# Altium schematic sheet JacdacAccessSwitchInput 34.SchDoc
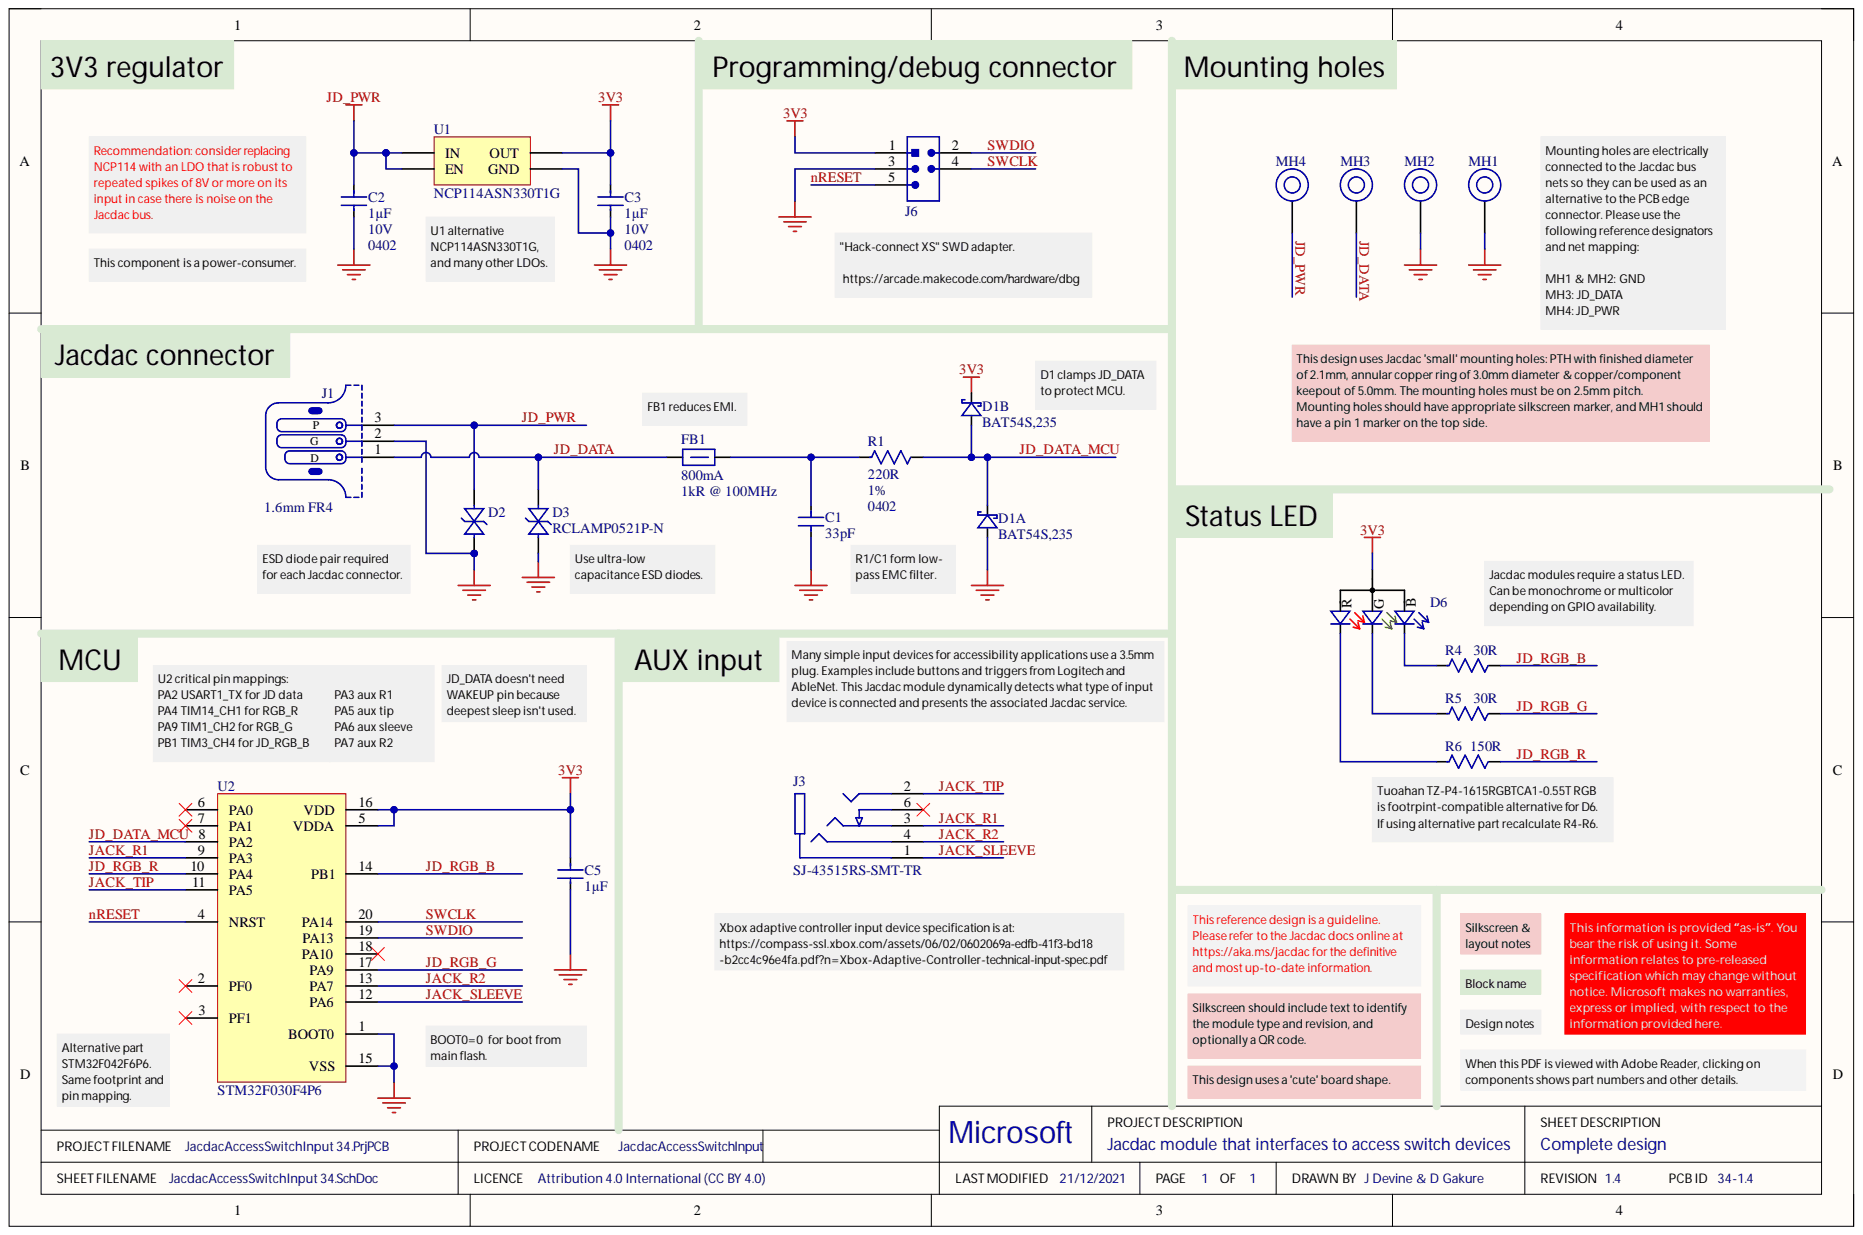
[diagram p0: JacdacAccessSwitchInput 34.SchDoc]

## Parts
C1  Capacitance: 33pF
C2  Capacitance: 1µF  Case Code (Imperial): 0402  Voltage Rating: 10V
C3  Capacitance: 1µF  Case Code (Imperial): 0402  Voltage Rating: 10V
C5  Capacitance: 1µF
D1  Manufacturer Part Number: BAT54S,235  (parts A,B)
D2  (no value)
D3  Manufacturer Part Number: RCLAMP0521P-N
D6  (no value)
FB1  Current Rating: 800mA  Impedance: 1kR @ 100MHz
J1  PCB Type: 1.6mm FR4
J3  Manufacturer Part Number: SJ-43515RS-SMT-TR
J6  (no value)
MH1  (no value)
MH2  (no value)
MH3  (no value)
MH4  (no value)
R1  Resistance: 220R  Tolerance: 1%
R4  Resistance: 30R
R5  Resistance: 30R
R6  Resistance: 150R
U1  Manufacturer Part Number: NCP114ASN330T1G
U2  Manufacturer Part Number: STM32F030F4P6

## Nets
3V3: C3.2 C5.2 D1.2 D6.1 J6.1 U1.5(OUT) U2.5(VDDA) U2.16(VDD)
GND: C1.1 C2.1 C3.1 C5.1 D1.1 D2.2 D3.2 J1.2 J6.3 MH1.1 MH2.1 U1.2(GND) U2.1(BOOT0) U2.15(VSS)
JACK_R1: J3.3 U2.9(PA3)
JACK_R2: J3.4 U2.13(PA7)
JACK_SLEEVE: J3.1 U2.12(PA6)
JACK_TIP: J3.2 U2.11(PA5)
JD_DATA: D3.1 FB1.1 J1.1 MH3.1
JD_DATA_MCU: D1.3 R1.1 U2.8(PA2)
JD_PWR: C2.2 D2.1 J1.3 MH4.1 U1.1(IN) U1.3(EN)
JD_RGB_B: R4.2 U2.14(PB1)
JD_RGB_G: R5.2 U2.17(PA9)
JD_RGB_R: R6.2 U2.10(PA4)
nRESET: J6.5 U2.4(NRST)
SWCLK: J6.4 U2.20(PA14)
SWDIO: J6.2 U2.19(PA13)
NetC1_2: C1.2 FB1.2 R1.2
NetD6_2: D6.2 R6.1
NetD6_3: D6.3 R5.1
NetD6_4: D6.4 R4.1

Not connected: J3 6; U2 2(PF0) 3(PF1) 6(PA0) 7(PA1) 18(PA10)

## Library data of the parts
C1 = (no symbol name): CAP CER 33PF 50V NP0 0402; Manufacturer: Samsung; Manufacturer Part Number: CL05C330JB51PNC; Tolerance: 5%; Case Code (Imperial): 0402; Case Code (Metric): 1005; Case/Package: 0402; Category: Capacitors; ComponentLink1Description: Samsung datasheet online; ComponentLink1URL: http://www.samsungsem.com/kr/support/library/product-catalog/mlcc.jsp; Max Operating Temperature: 125°C; Min Operating Temperature: -55°C; Ratings: AEC-Q200; Supplier 1: Digi-Key; Supplier 2: Mouser; Supplier 3: Future Electronics; Supplier Part Number 1: 1276-6515-1-ND; Supplier Part Number 2: 187-CL05C330JB51PNC; Supplier Part Number 3: 6022520; Voltage Rating: 50V
C2 C3 C5 = (no symbol name): CAP CER 1UF 10V X5R 0402; Manufacturer: Samsung; Manufacturer Part Number: CL05A105KP5NNNC; Material: Ceramic; Tolerance: 10%; Case Code (Metric): 1005; Case/Package: 0402; Category: Capacitors; ComponentLink1Description: Online datasheet; ComponentLink1URL: https://datasheet.ciiva.com/15195/getdatasheetpartid-358390-15195630.pdf; Contact Plating: Tin; Dielectric: X5R; Max Operating Temperature: 85°C; Min Operating Temperature: -55°C; Supplier 1: Digi-Key; Supplier 2: Mouser; Supplier 3: Farnell; Supplier Part Number 1: 1276-1076-1-ND; Supplier Part Number 2: 187-CL05A105KP5NNNC; Supplier Part Number 3: 3013329
  C5: Case Code (Imperial): 0402; Voltage Rating: 10V
D1 = (no symbol name): DIODE ARRAY SCHOTTKY 30V SOT23; Manufacturer: Nexperia; Category: Rectifier Diodes; ComponentLink1Description: NXP datasheet online; ComponentLink1URL: https://assets.nexperia.com/documents/data-sheet/BAT54_SER.pdf; Supplier 1: Digi-Key; Supplier 2: Mouser; Supplier 3: RSComponents; Supplier Part Number 1: 1727-1868-1-ND; Supplier Part Number 2: 771-BAT54S235; Supplier Part Number 3: 1707990
D2 = (no symbol name): Bidirectional 5V (Max) 6V 16V 3.5A (8/20us) 0402 TVS RoHS; Manufacturer: Born Semiconductor; Manufacturer Part Number: RCLAMP0521P-N; ComponentLink1Description: Online datasheet; ComponentLink1URL: https://datasheet.lcsc.com/szlcsc/Bourne-Semicon-Shenzhen-RCLAMP0521P-N_C316043.pdf; Package: 0402; Supplier1: LCSC; Supplier1 Part Number: C316043
D3 = (no symbol name): Bidirectional 5V (Max) 6V 16V 3.5A (8/20us) 0402 TVS RoHS; Manufacturer: Born Semiconductor; ComponentLink1Description: Online datasheet; ComponentLink1URL: https://datasheet.lcsc.com/szlcsc/Bourne-Semicon-Shenzhen-RCLAMP0521P-N_C316043.pdf; Package: 0402; Supplier1: LCSC; Supplier1 Part Number: C316043
D6 = (no symbol name): Red, Green, Blue (RGB) 626nm Red, 525nm Green, 470nm Blue LED Indication - Discrete 1.9V Red, 3.4V Green, 3.4V Blue 0606 (1616 Metric); Manufacturer: Broadcom Avago; Manufacturer Part Number: HSMF-C114; Color: Blue,Green,Red; Forward Current: 20mA; Lens Color: White; Lens Style: Diffused,Rectangular; Lens Transparency: Diffused; Luminous Intensity: 70mcd; Number of Elements: 3; Power Rating: 78mW; Reverse Voltage: 5V; Viewing Angle: 145°; Case Code (Imperial): 0606; Case Code (Metric): 1616; Case/Package: 0606; Category: Optoelectronics; ComponentLink1Description: Online datasheet; ComponentLink1URL: https://docs.broadcom.com/docs/AV02-2451EN; Dominant Wavelength: 470nm; Forward Voltage: 3.4V; Max Operating Temperature: 85°C; Min Operating Temperature: -40°C; Number of LEDs: 3; Number of Pins: 4; Supplier 1: Digi-Key; Supplier 2: Farnell; Supplier 3: Mouser; Supplier Part Number 1: 516-1795-1-ND; Supplier Part Number 2: 1058362; Supplier Part Number 3: 630-HSMF-C114; Test Current: 20mA; Wavelength: 470nm
FB1 = (no symbol name): 0603 1k@100MHz 0.8A chip; Manufacturer: TDK; Manufacturer Part Number: MPZ1608S102ATA00; Core Material: Ferrite; DC Resistance (DCR): 300mR; Tolerance: 25%; Case Code (Imperial): 0603; Case Code (Metric): 1608; Case/Package: 0603; ComponentLink2Description: datasheet online; ComponentLink2URL: https://www.mouser.co.uk/datasheet/2/400/eads_commercial_power_mpz1608_en-923650.pdf; ComponentLink3Description: datasheet; Max DC Current: 800mA; Supplier 1: Digi-Key; Supplier 2: Mouser; Supplier Part Number 1: 445-8694-1-ND; Supplier Part Number 2: 810-MPZ1608S102ATA00; ComponentLink3URL: Y:\System\Altium\Libraries 2014\TDK\Datasheets\eads_commercial_power_mpz1608_en-923650.pdf
J1 = (no symbol name): 3 pin Jacdac edge connector 1.27mm pitch; Manufacturer Part Number: JACDAC_EDGE_CONNECTOR; ComponentLink1Description: Yuliang JACDAC cable connector drawing online; ComponentLink1URL: https://github.com/microsoft/jacdac-mdk/blob/main/connector/JACDAC-Connector-by-Yuliang-2020-11-28.pdf; ComponentLink2Description: JACDAC PCB edge connector spec onlin; ComponentLink2URL: https://github.com/microsoft/jacdac-mdk/blob/main/connector/JACDAC_PCB_Edge_Connector_Drawing_JD-PEC-01_rev_01.pdf
J3 = (no symbol name): 4-way 3.5mm jack socket SMD R/A TRRS; Manufacturer: CUI; Number of Conductors: 5; Number of Ports: 1; Shielding: Unshielded; Color: Black; ComponentLink1Description: CUI datasheet; ComponentLink1URL: https://www.cuidevices.com/product/resource/sj-4351x-smt.pdf; Connector Type: Jack; Orientation: Horizontal; Supplier 1: Digi-Key; Supplier Part Number 1: CP-43515RSSJCT-ND
J6 = (no symbol name): Hack Connect Mini 1.27 mm pitch 2-row
MH1-MH4 = (no symbol name): Mounting Hole 5.0mm keepout, 3.0mm annualar ring and 2.1mm Drill PTH
R1 = (no symbol name): RES SMD 220 OHM 1% 1/16W 0402; Manufacturer: Uniroyal Elec; Manufacturer Part Number: 0402WGF2200TCE; Case Code (Imperial): 0402; ComponentLink1Description: datasheet (offline); ComponentLink1URL: Y:\System\Altium\Libraries 2014\Uniroyal Elec\Datasheets\Uniro
R4 R5 = (no symbol name): RES SMD 30 OHM 1% 1/16W 0402; Manufacturer: Uniroyal Elec; Manufacturer Part Number: 0402WGF300JTCE; Case Code (Imperial): 0402; ComponentLink1Description: datasheet (offline); Tolerance: 1%; ComponentLink1URL: Y:\System\Altium\Libraries 2014\Uniroyal Elec\Datasheets\Uniro
R6 = (no symbol name): RES SMD 150 OHM 1% 1/16W 0402; Manufacturer: Uniroyal Elec; Manufacturer Part Number: 0402WGF1500TCE; Case Code (Imperial): 0402; ComponentLink1Description: datasheet (offline); Tolerance: 1%; ComponentLink1URL: Y:\System\Altium\Libraries 2014\Uniroyal Elec\Datasheets\Uniro
U1 = (no symbol name): ON SEMICONDUCTOR - NCP114ASN330T1G - LDO Voltage Regulator, Fixed, 1.7 V to 5.5 V in, 3.3 V/300 mA out, 150 mV drop, TSOP-5; Dropout Voltage: 225mV; Max Input Voltage: 5.5V; Min Output Voltage: 3.3V; Number of Regulators: 1; Output Configuration: Positive; Output Current: 300mA; Power Supply Rejection Ratio (PSRR): 75dB; Quiescent Current: 95uA; Case/Package: SOT-23-5; Category: Voltage Regulators - Linear; ComponentLink3Description: On Semi datasheet online; ComponentLink3URL: http://www.onsemi.com/pub/Collateral/NCP114-D.PDF; Manufacturer: ON Semiconductor; Max Operating Temperature: 85°C; Min Operating Temperature: -40°C; Output Type: Fixed; Supplier 1: Digi-Key; Supplier 2: RSComponents; Supplier 3: Mouser; Supplier Part Number 1: NCP114ASN330T1GOSCT-ND; Supplier Part Number 2: 1263178; Supplier Part Number 3: 863-NCP114ASN330T1G
U2 = (no symbol name): STMICROELECTRONICS - STM32F030F4P6 - 32 Bit Microcontroller, Value Line, ARM Cortex-M0, 48 MHz, 16 KB, 4 KB, 20, TSSOP RoHS Compliant: Yes; Categories: Integrated Circuits; Data Bus Width: 32b; Frequency: 48MHz; Interface: I2C,SPI,UART,USART; Max Power Dissipation: 182mW; Max Supply Voltage: 3.6V; Memory Size: 16KB; Memory Type: FLASH; Min Supply Voltage: 2.4V; Number of ADC Channels: 16; Number of I2C Channels: 1; Number of I/Os: 15; Number of PWM Channels: 6; Number of Timers/Counters: 5; Oscillator Type: Internal; Peripherals: POR,PWM,WDT,DMA; RAM Size: 4KB; Case/Package: TSSOP; Category: Microcontrollers; ComponentLink1Description: Online datasheet; ComponentLink1URL: https://datasheet.ciiva.com/29720/dm00088500-29720640.pdf; Contact Plating: Gold; Core Architecture: ARM; Manufacturer: STMicroelectronics; Max Frequency: 48MHz; Max Operating Temperature: 85°C; Min Operating Temperature: -40°C; Number of Pins: 20; Number of Programmable I/O: 15; Number of SPI Channels: 1; Supplier 1: Farnell; Supplier 2: Digi-Key; Supplier 3: RSComponents; Supplier Part Number 1: 2393635; Supplier Part Number 2: 497-14044-5-ND; Supplier Part Number 3: 7925925P; Watchdog Timer: Yes
